# Altium schematic sheet pmSpaceWire.SchDoc(pmSpaceWire)
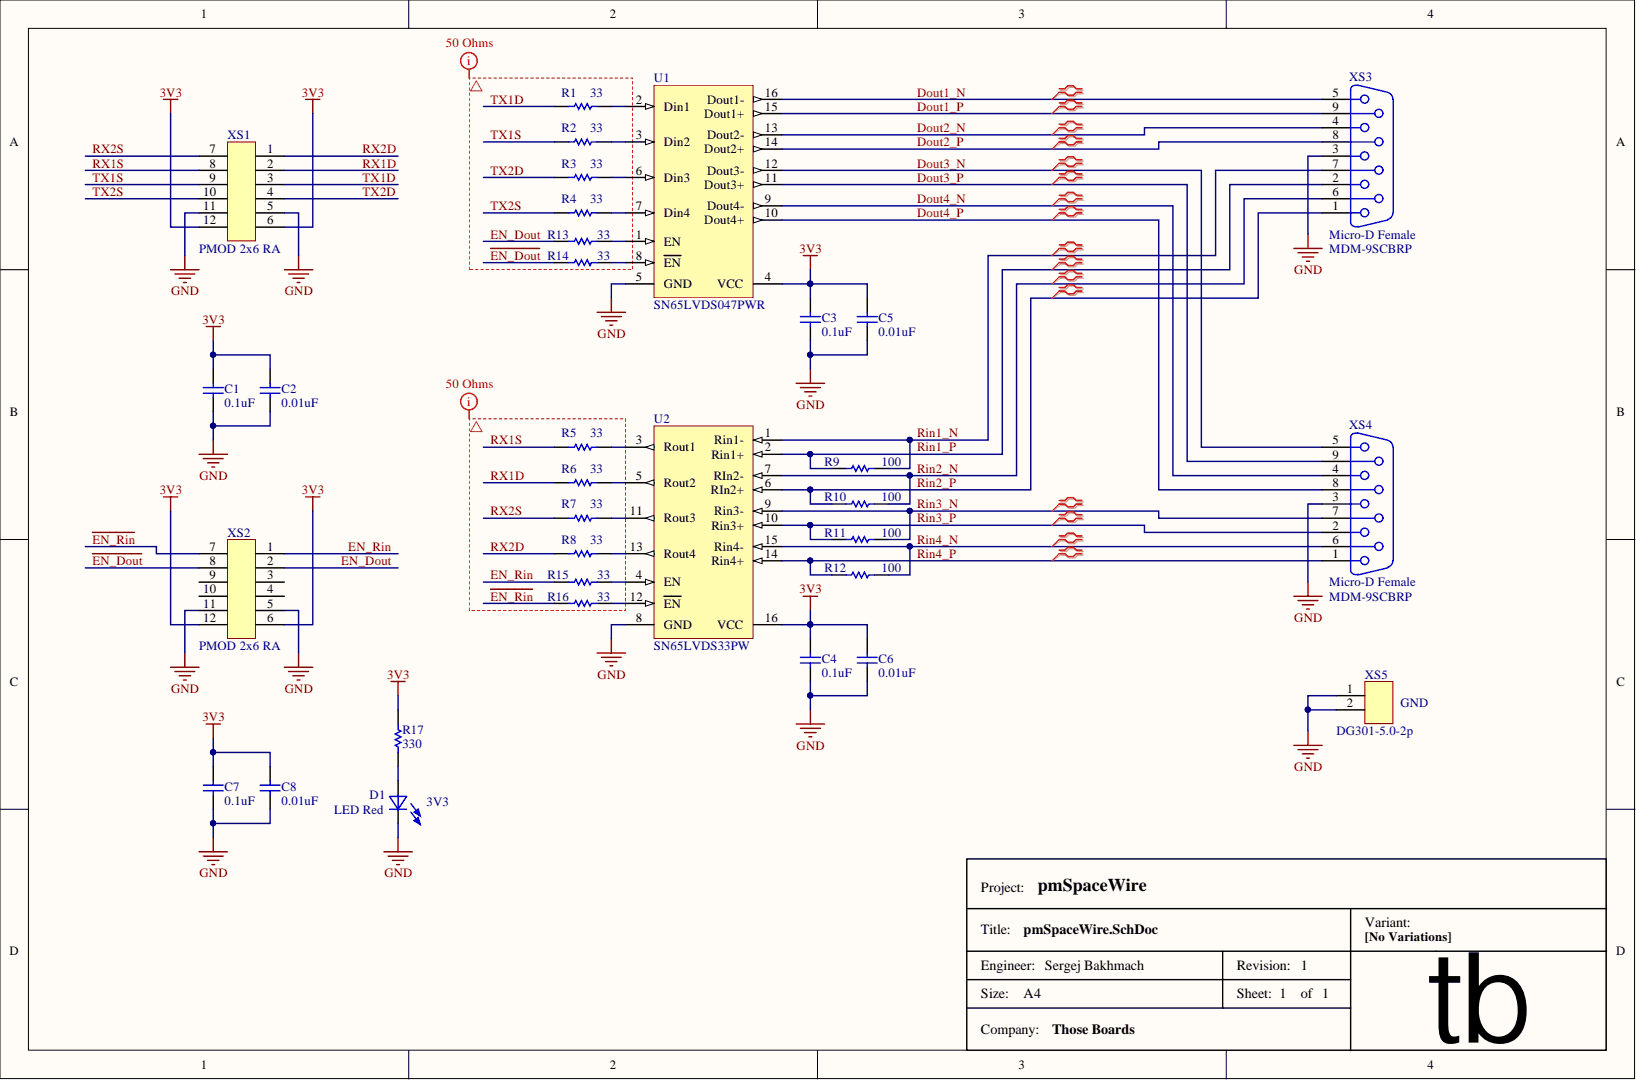
[diagram p0: pmSpaceWire.SchDoc]

## Parts
C1  value 0.1uF  [C0603]  (hidden comment: Capacitor)
C2  value 0.01uF  [C0603]  (hidden comment: Capacitor)
C3  value 0.1uF  [C0603]  (hidden comment: Capacitor)
C4  value 0.1uF  [C0603]  (hidden comment: Capacitor)
C5  value 0.01uF  [C0603]  (hidden comment: Capacitor)
C6  value 0.01uF  [C0603]  (hidden comment: Capacitor)
C7  value 0.1uF  [C0603]  (hidden comment: Capacitor)
C8  value 0.01uF  [C0603]  (hidden comment: Capacitor)
D1  3V3  value LED Red  [0805_LED_red]
R1  33  [0402_RESISTOR]
R2  33  [0402_RESISTOR]
R3  33  [0402_RESISTOR]
R4  33  [0402_RESISTOR]
R5  33  [0402_RESISTOR]
R6  33  [0402_RESISTOR]
R7  33  [0402_RESISTOR]
R8  33  [0402_RESISTOR]
R9  100  [0402_RESISTOR]
R10  100  [0402_RESISTOR]
R11  100  [0402_RESISTOR]
R12  100  [0402_RESISTOR]
R13  33  [0402_RESISTOR]
R14  33  [0402_RESISTOR]
R15  33  [0402_RESISTOR]
R16  33  [0402_RESISTOR]
R17  330  [0603_RESISTOR]
U1  SN65LVDS047PWR  [TSOP65P640X120-16N]
U2  SN65LVDS33  value SN65LVDS33PW  [TSOP65P640X120-16N]
XS1  PMOD 2x6 RA  [Conn_PMOD_2x6_RA_M]
XS2  PMOD 2x6 RA  [Conn_PMOD_2x6_RA_M]
XS3  Micro-D  value Micro-D Female  Manufacturer Part: MDM-9SCBRP  [CONN_MDM-9SCBRP]
XS4  Micro-D  value Micro-D Female  Manufacturer Part: MDM-9SCBRP  [CONN_MDM-9SCBRP]
XS5  GND  value DG301-5.0-2p  [CONN_DG301-5.0-2P]

## Nets
3V3: C1.2 C2.2 C3.2 C4.2 C5.2 C6.2 C7.2 C8.2 R17.2 U1.4(VCC) U2.16(VCC) XS1.6 XS1.12 XS2.6 XS2.12
GND: C1.1 C2.1 C3.1 C4.1 C5.1 C6.1 C7.1 C8.1 D1.2 U1.5(GND) U2.8(GND) XS1.5 XS1.11 XS2.5 XS2.11 XS3.3 XS4.3 XS5.1 XS5.2
Dout1_N: U1.16(Dout1-) XS3.5
Dout1_P: U1.15(Dout1+) XS3.9
Dout2_N: U1.13(Dout2-) XS3.4
Dout2_P: U1.14(Dout2+) XS3.8
Dout3_N: U1.12(Dout3-) XS4.5
Dout3_P: U1.11(Dout3+) XS4.9
Dout4_N: U1.9(Dout4-) XS4.4
Dout4_P: U1.10(Dout4+) XS4.8
~{EN_Dout}: R14.1 XS2.8
~{EN_Rin}: R16.1 XS2.7
EN_Dout: R13.1 XS2.2
EN_Rin: R15.1 XS2.1
Rin1_N: R9.2 U2.1(Rin1-) XS3.7
Rin1_P: R9.1 U2.2(Rin1+) XS3.2
Rin2_N: R10.2 U2.7(RIn2-) XS3.6
Rin2_P: R10.1 U2.6(RIn2+) XS3.1
Rin3_N: R11.2 U2.9(Rin3-) XS4.7
Rin3_P: R11.1 U2.10(Rin3+) XS4.2
Rin4_N: R12.2 U2.15(Rin4-) XS4.6
Rin4_P: R12.1 U2.14(Rin4+) XS4.1
RX1D: R6.1 XS1.2
RX1S: R5.1 XS1.8
RX2D: R8.1 XS1.1
RX2S: R7.1 XS1.7
TX1D: R1.1 XS1.3
TX1S: R2.1 XS1.9
TX2D: R3.1 XS1.4
TX2S: R4.1 XS1.10
NetD1_1: D1.1 R17.1
NetR1_2: R1.2 U1.2(Din1)
NetR2_2: R2.2 U1.3(Din2)
NetR3_2: R3.2 U1.6(Din3)
NetR4_2: R4.2 U1.7(Din4)
NetR5_2: R5.2 U2.3(Rout1)
NetR6_2: R6.2 U2.5(Rout2)
NetR7_2: R7.2 U2.11(Rout3)
NetR8_2: R8.2 U2.13(Rout4)
NetR13_2: R13.2 U1.1(EN)
NetR14_2: R14.2 U1.8(EN)
NetR15_2: R15.2 U2.4(EN)
NetR16_2: R16.2 U2.12(EN)
N00015:
N00016:

Not connected: XS2 3 4 9 10

## Library data of the parts
C1-C8 = Cap: Component Kind: Standard; Library Name: thoseboards.IntLib
D1 = LED: Description: LED; Component Kind: Standard; Library Name: thoseboards.IntLib
R1-R17 = Res: Resistor; Component Kind: Standard; Library Name: thoseboards.IntLib
  R1-R8 R13-R16: Value: 33
  R9-R12: Value: 100
  R17: Value: 330
U1 = SN65LVDS047: LVDS Driver Interface IC Quad Transmitter w/Flow-Thru Pinout; Manufacturer: TI; Value: SN65LVDS047PWR; Type of Component: LVDS Driver; Package / Case: TSSOP-16; Manufacturer Part: SN65LVDS047PWR; Component Kind: Standard; Library Name: thoseboards.IntLib
U2 = SN65LVDS33: LVDS Receiver Interface IC Quad HS Diff; Manufacturer: TI; Type of Component: LVDS Receiver; Package / Case: TSSOP-16; Manufacturer Part: SN65LVDS33PW; Component Kind: Standard; Library Name: thoseboards.IntLib
XS1 XS2 = Conn_PMOD_2x6_RA_M: PMOD 2x6 Right Angle, Male; Value: PMOD 2x6 RA; Component Kind: Standard; Library Name: thoseboards.IntLib
XS3 XS4 = Conn_Micro-D: Micro-D connector; Manufacturer: ITTCannon; Component Kind: Standard; Library Name: thoseboards.IntLib
XS5 = Conn_DG301-5.0-2p: Component Kind: Standard; Library Name: thoseboards.IntLib
